# Altium schematic sheet Module_iMX8_plus.SchDoc
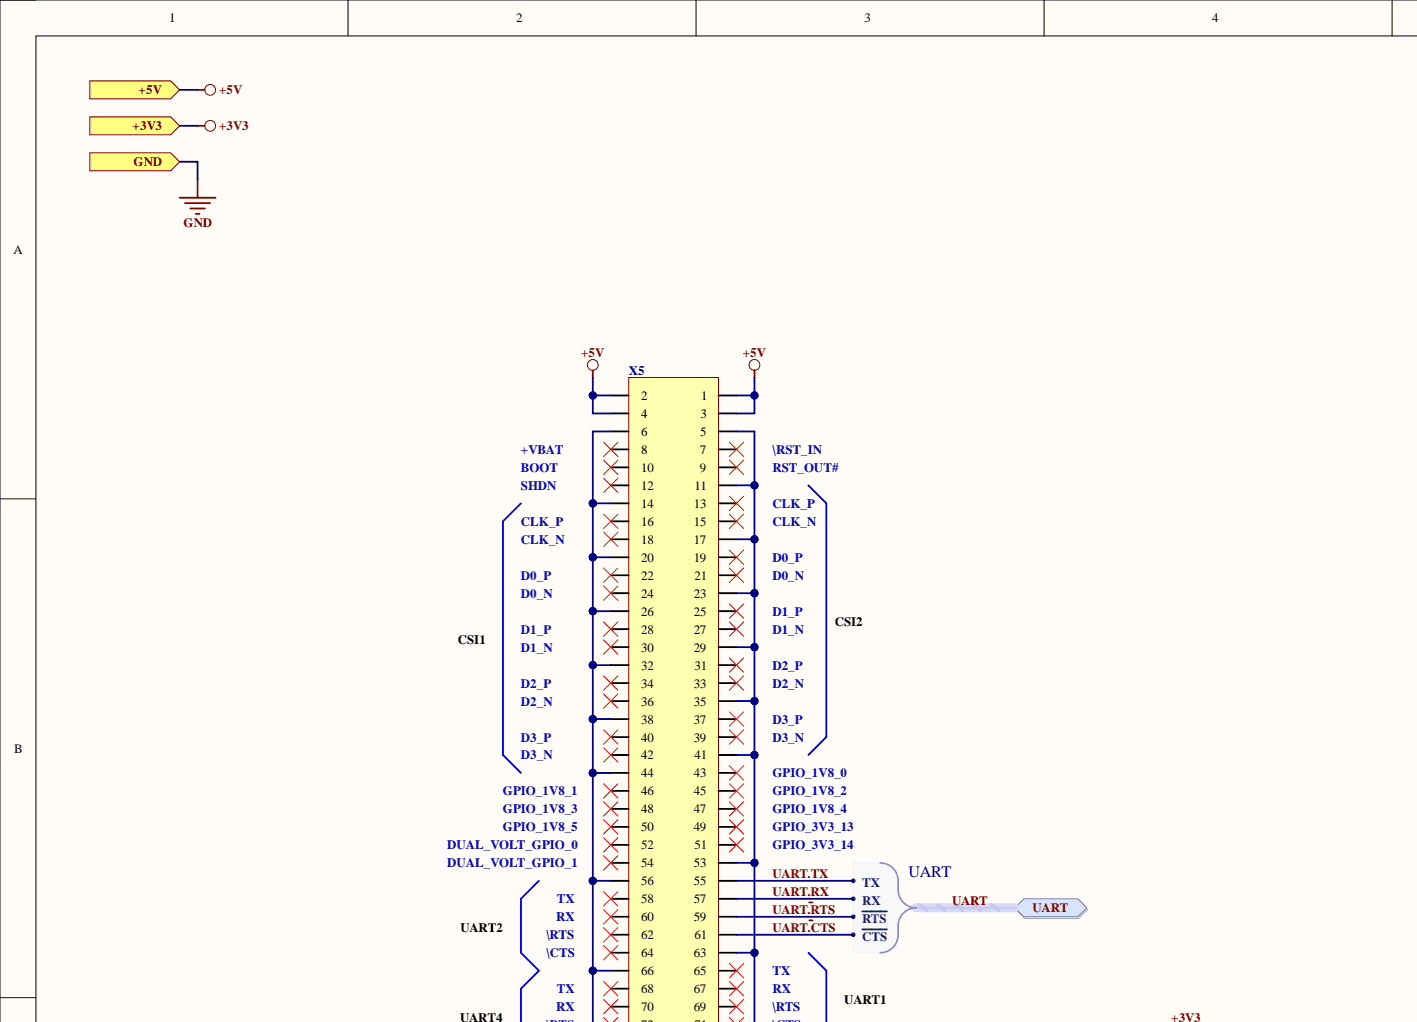
[diagram p0: Module_iMX8_plus.SchDoc, top left]
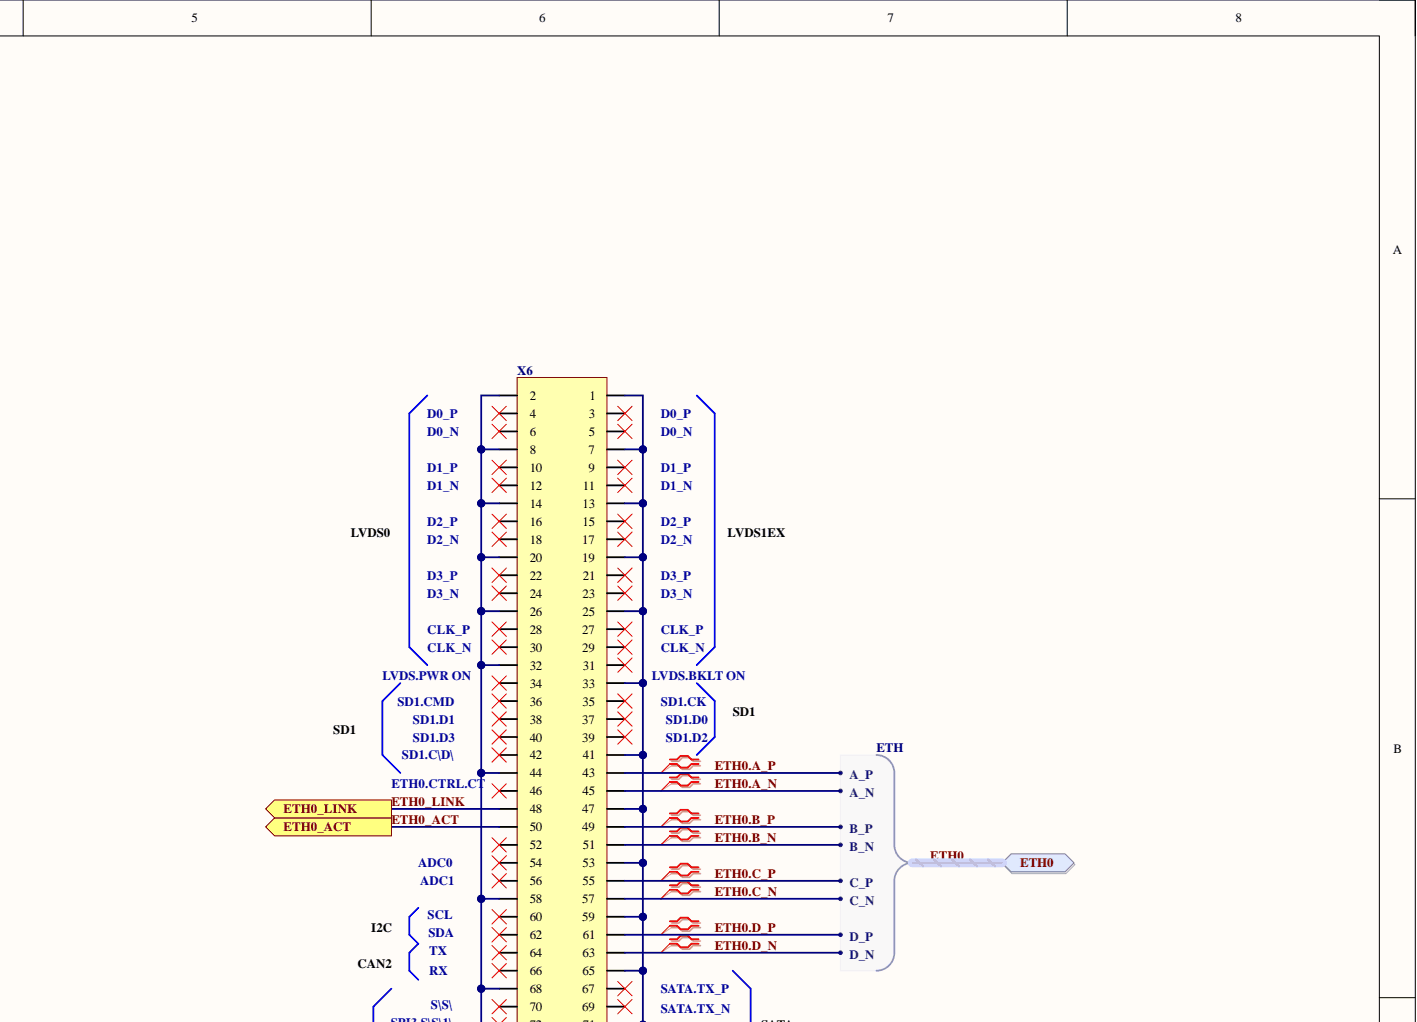
[diagram p1: Module_iMX8_plus.SchDoc, top right]
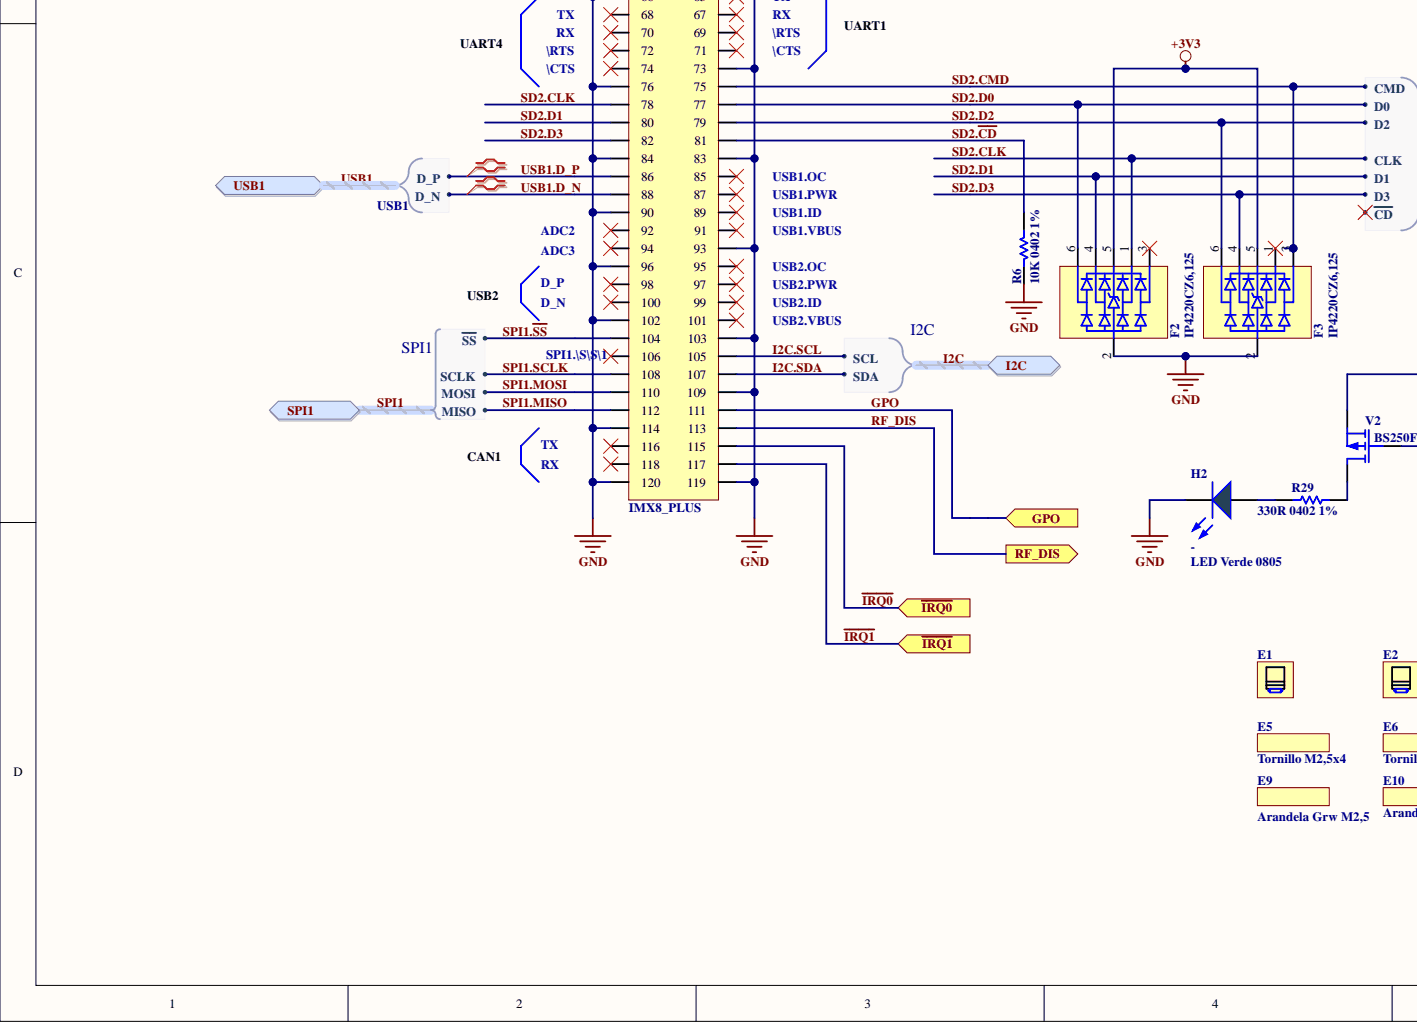
[diagram p2: Module_iMX8_plus.SchDoc, bottom left]
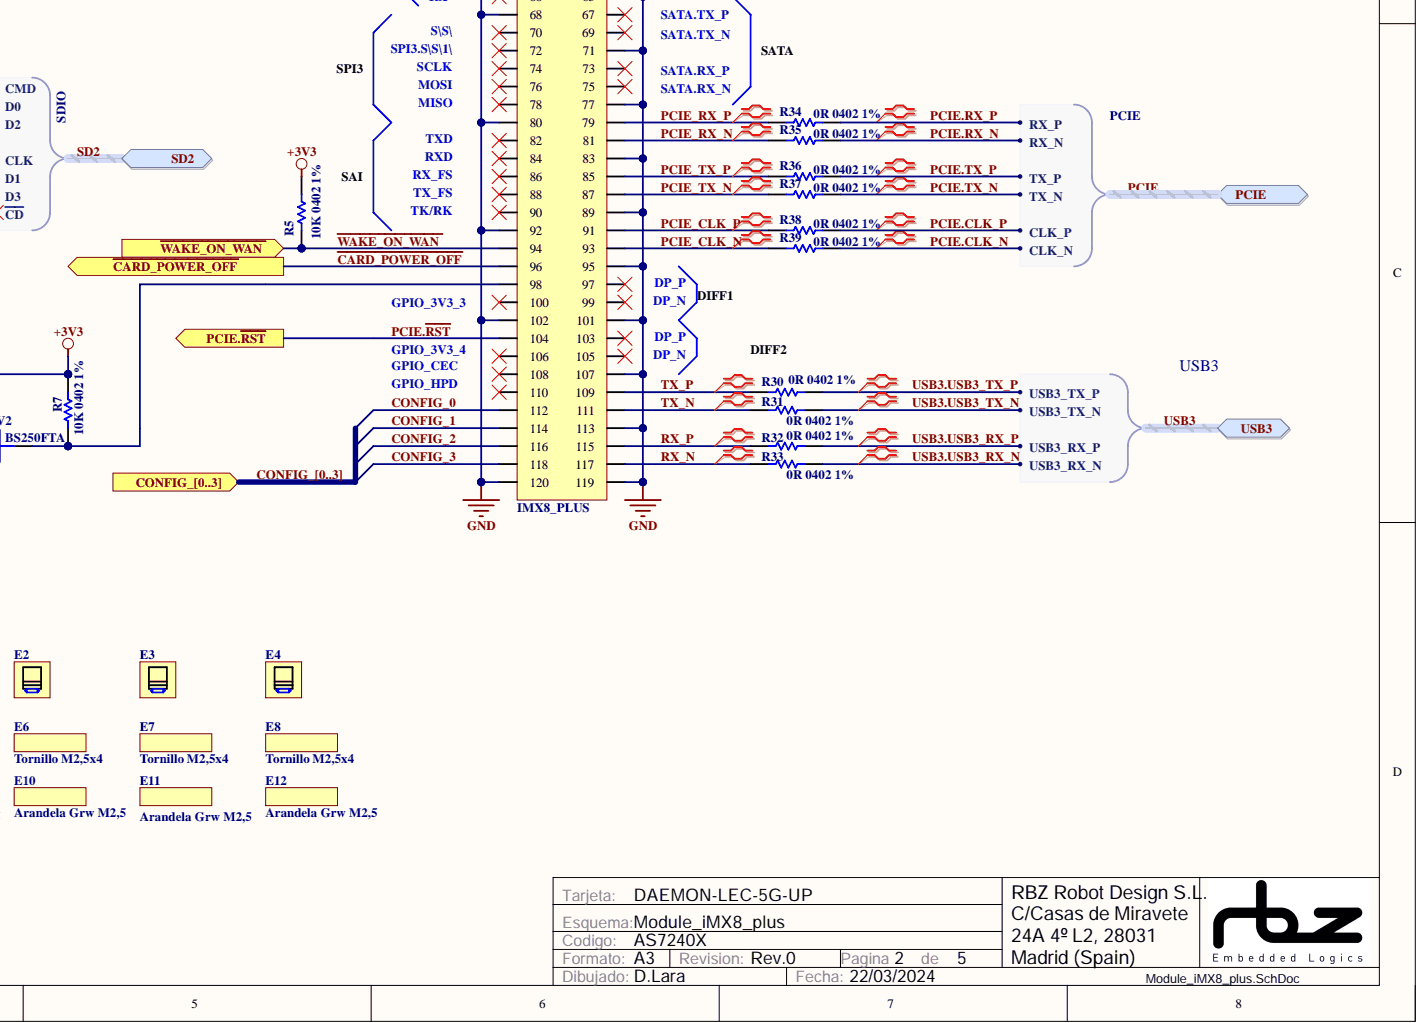
[diagram p3: Module_iMX8_plus.SchDoc, bottom right]

## Parts
E1  ROUND STANDOFF M2.5 STEEL 5MM  [9774050151R]  (value not drawn)
E2  ROUND STANDOFF M2.5 STEEL 5MM  [9774050151R]  (value not drawn)
E3  ROUND STANDOFF M2.5 STEEL 5MM  [9774050151R]  (value not drawn)
E4  ROUND STANDOFF M2.5 STEEL 5MM  [9774050151R]  (value not drawn)
E5  Tornillo M2,5x4  (mechanical)
E6  Tornillo M2,5x4  (mechanical)
E7  Tornillo M2,5x4  (mechanical)
E8  Tornillo M2,5x4  (mechanical)
E9  Arandela Grw M2,5  (mechanical)
E10  Arandela Grw M2,5  (mechanical)
E11  Arandela Grw M2,5  (mechanical)
E12  Arandela Grw M2,5  (mechanical)
F2  IP4220CZ6,125  [SOT26A-6N]
F3  IP4220CZ6,125  [SOT26A-6N]
H2  -  value LED Verde 0805  Type: LED  [D0805(2012)]
R5  10K 0402 1%  [R0402(1005)-HIGH_DENSITY]
R6  10K 0402 1%  [R0402(1005)-HIGH_DENSITY]
R7  10K 0402 1%  [R0402(1005)-HIGH_DENSITY]
R29  330R 0402 1%  [R0402(1005)-HIGH_DENSITY]
R30  0R 0402 1%  [R0402(1005)-HIGH_DENSITY]
R31  0R 0402 1%  [R0402(1005)-HIGH_DENSITY]
R32  0R 0402 1%  [R0402(1005)-HIGH_DENSITY]
R33  0R 0402 1%  [R0402(1005)-HIGH_DENSITY]
R34  0R 0402 1%  [R0402(1005)-HIGH_DENSITY]
R35  0R 0402 1%  [R0402(1005)-HIGH_DENSITY]
R36  0R 0402 1%  [R0402(1005)-HIGH_DENSITY]
R37  0R 0402 1%  [R0402(1005)-HIGH_DENSITY]
R38  0R 0402 1%  [R0402(1005)-HIGH_DENSITY]
R39  0R 0402 1%  [R0402(1005)-HIGH_DENSITY]
V2  BS250FTA  [SOT23]
X5  IMX8_PLUS  [FCI_61083-121402LF]
X6  IMX8_PLUS  [FCI_61083-121402LF]

## Ports
+3V3, +5V, CONFIG_0, CONFIG_1, CONFIG_2, CONFIG_3, CONFIG_[0..3], ETH0, ETH0.A_N, ETH0.A_P, ETH0.B_N, ETH0.B_P, ETH0.C_N, ETH0.C_P, ETH0.D_N, ETH0.D_P, ETH0_ACT, ETH0_LINK, GND, GPO, I2C, I2C.SCL, I2C.SDA, PCIE, PCIE.CLK_N, PCIE.CLK_P, PCIE.RX_N, PCIE.RX_P, PCIE.TX_N, PCIE.TX_P, PCIE.~{RST}, RF_DIS, SD2, SD2.CLK, SD2.CMD, SD2.D0, SD2.D1, SD2.D2, SD2.D3, SD2.~{CD}, SPI1, SPI1.MISO, SPI1.MOSI, SPI1.SCLK, SPI1.~{SS}, UART, UART.RX, UART.TX, UART~{.}CTS, UART~{.}RTS, USB1, USB1.D_N, USB1.D_P, USB3, USB3.USB3_RX_N, USB3.USB3_RX_P, USB3.USB3_TX_N, USB3.USB3_TX_P, ~{CARD_POWER_OFF}, ~{IRQ0}, ~{IRQ1}, ~{WAKE_ON_WAN}

## Nets
+3V3: F2.5 F3.5 R5.1 R7.1 V2.2 ; port +3V3
+5V: X5.1 X5.2 X5.3 X5.4 ; port +5V
~{CARD_POWER_OFF}: X6.96 ; port ~{CARD_POWER_OFF}
~{IRQ0}: X5.115 ; port ~{IRQ0}
~{IRQ1}: X5.117 ; port ~{IRQ1}
~{WAKE_ON_WAN}: R5.2 X6.94 ; port ~{WAKE_ON_WAN}
CONFIG_0: X6.112 ; port CONFIG_[0..3]  [also labelled CONFIG_]
CONFIG_1: X6.114 ; port CONFIG_[0..3]  [also labelled CONFIG_]
CONFIG_2: X6.116 ; port CONFIG_[0..3]  [also labelled CONFIG_]
CONFIG_3: X6.118 ; port CONFIG_[0..3]  [also labelled CONFIG_]
ETH0.A_N: X6.45 X6.48 X6.50 ; port ETH0, port ETH0_ACT, port ETH0_LINK  [also labelled ETH0_ACT, ETH0_LINK]
ETH0.A_P: X6.43 ; port ETH0
ETH0.B_N: X6.51 ; port ETH0
ETH0.B_P: X6.49 ; port ETH0
ETH0.C_N: X6.57 ; port ETH0
ETH0.C_P: X6.55 ; port ETH0
ETH0.D_N: X6.63 ; port ETH0
ETH0.D_P: X6.61 ; port ETH0
GND: F2.2 F3.2 H2.2 R6.1 X5.5 X5.6 X5.11 X5.14 X5.17 X5.20 X5.23 X5.26 X5.29 X5.32 X5.35 X5.38 X5.41 X5.44 X5.53 X5.56 X5.63 X5.66 X5.73 X5.76 X5.83 X5.84 X5.90 X5.93 X5.96 X5.102 X5.103 X5.109 X5.114 X5.119 X5.120 X6.1 X6.2 X6.7 X6.8 X6.13 X6.14 X6.19 X6.20 X6.25 X6.26 X6.32 X6.33 X6.41 X6.44 X6.47 X6.53 X6.58 X6.59 X6.65 X6.68 X6.71 X6.77 X6.80 X6.83 X6.89 X6.92 X6.95 X6.101 X6.102 X6.107 X6.113 X6.119 X6.120 ; port GND
GPO: X5.111 ; port GPO
I2C.SCL: X5.105 ; port I2C
I2C.SDA: X5.107 ; port I2C
PCIE.CLK_N: R39.2 ; port PCIE
PCIE.CLK_P: R38.2 ; port PCIE
PCIE.~{RST}: X6.104 ; port PCIE.~{RST}
PCIE.RX_N: R35.2 ; port PCIE
PCIE.RX_P: R34.2 ; port PCIE
PCIE.TX_N: R37.2 ; port PCIE
PCIE.TX_P: R36.2 ; port PCIE
PCIE_CLK_N: R39.1 X6.93
PCIE_CLK_P: R38.1 X6.91
PCIE_RX_N: R35.1 X6.81
PCIE_RX_P: R34.1 X6.79
PCIE_TX_N: R37.1 X6.87
PCIE_TX_P: R36.1 X6.85
RF_DIS: X5.113 ; port RF_DIS
RX_N: R33.1 X6.117
RX_P: R32.1 X6.115
SD2.~{CD}: R6.2 X5.81 ; port SD2
SD2.CLK: F2.1 X5.78 ; port SD2
SD2.CMD: F3.3 X5.75 ; port SD2
SD2.D0: F2.6 X5.77 ; port SD2
SD2.D1: F2.4 X5.80 ; port SD2
SD2.D2: F3.6 X5.79 ; port SD2
SD2.D3: F3.4 X5.82 ; port SD2
SPI1.MISO: X5.112 ; port SPI1
SPI1.MOSI: X5.110 ; port SPI1
SPI1.~{SS}: X5.104 ; port SPI1
SPI1.SCLK: X5.108 ; port SPI1
TX_N: R31.1 X6.111
TX_P: R30.1 X6.109
UART~{.}CTS: X5.61 ; port UART
UART~{.}RTS: X5.59 ; port UART
UART.RX: X5.57 ; port UART
UART.TX: X5.55 ; port UART
USB1.D_N: X5.88 ; port USB1
USB1.D_P: X5.86 ; port USB1
USB3.USB3_RX_N: R33.2 ; port USB3
USB3.USB3_RX_P: R32.2 ; port USB3
USB3.USB3_TX_N: R31.2 ; port USB3
USB3.USB3_TX_P: R30.2 ; port USB3
NetF2_3: F2.3
NetF3_1: F3.1
NetH2_1: H2.1 R29.1
NetR7_2: R7.2 V2.1 X6.98
NetR29_2: R29.2 V2.3
NetX5_7: X5.7
NetX5_8: X5.8
NetX5_9: X5.9
NetX5_10: X5.10
NetX5_12: X5.12
NetX5_13: X5.13
NetX5_15: X5.15
NetX5_16: X5.16
NetX5_18: X5.18
NetX5_19: X5.19
NetX5_21: X5.21
NetX5_22: X5.22
NetX5_24: X5.24
NetX5_25: X5.25
NetX5_27: X5.27
NetX5_28: X5.28
NetX5_30: X5.30
NetX5_31: X5.31
NetX5_33: X5.33
NetX5_34: X5.34
NetX5_36: X5.36
NetX5_37: X5.37
NetX5_39: X5.39
NetX5_40: X5.40
NetX5_42: X5.42
NetX5_43: X5.43
NetX5_45: X5.45
NetX5_46: X5.46
NetX5_47: X5.47
NetX5_48: X5.48
NetX5_49: X5.49
NetX5_50: X5.50
NetX5_51: X5.51
NetX5_52: X5.52
NetX5_54: X5.54
NetX5_58: X5.58
NetX5_60: X5.60
NetX5_62: X5.62
NetX5_64: X5.64
NetX5_65: X5.65
NetX5_67: X5.67
NetX5_68: X5.68
NetX5_69: X5.69
NetX5_70: X5.70
NetX5_71: X5.71
NetX5_72: X5.72
NetX5_74: X5.74
NetX5_85: X5.85
NetX5_87: X5.87
NetX5_89: X5.89
NetX5_91: X5.91
NetX5_92: X5.92
NetX5_94: X5.94
NetX5_95: X5.95
NetX5_97: X5.97
NetX5_98: X5.98
NetX5_99: X5.99
NetX5_100: X5.100
NetX5_101: X5.101
NetX5_106: X5.106
NetX5_116: X5.116
NetX5_118: X5.118
NetX6_3: X6.3
NetX6_4: X6.4
NetX6_5: X6.5
NetX6_6: X6.6
NetX6_9: X6.9
NetX6_10: X6.10
NetX6_11: X6.11
NetX6_12: X6.12
NetX6_15: X6.15
NetX6_16: X6.16
NetX6_17: X6.17
NetX6_18: X6.18
NetX6_21: X6.21
NetX6_22: X6.22
NetX6_23: X6.23
NetX6_24: X6.24
NetX6_27: X6.27
NetX6_28: X6.28
NetX6_29: X6.29
NetX6_30: X6.30
NetX6_31: X6.31
NetX6_34: X6.34
NetX6_35: X6.35
NetX6_36: X6.36
NetX6_37: X6.37
NetX6_38: X6.38
NetX6_39: X6.39
NetX6_40: X6.40
NetX6_42: X6.42
NetX6_46: X6.46
NetX6_52: X6.52
NetX6_54: X6.54
NetX6_56: X6.56
NetX6_60: X6.60
NetX6_62: X6.62
NetX6_64: X6.64
NetX6_66: X6.66
NetX6_67: X6.67
NetX6_69: X6.69
NetX6_70: X6.70
NetX6_72: X6.72
NetX6_73: X6.73
NetX6_74: X6.74
NetX6_75: X6.75
NetX6_76: X6.76
NetX6_78: X6.78
NetX6_82: X6.82
NetX6_84: X6.84
NetX6_86: X6.86
NetX6_88: X6.88
NetX6_90: X6.90
NetX6_97: X6.97
NetX6_99: X6.99
NetX6_100: X6.100
NetX6_103: X6.103
NetX6_105: X6.105
NetX6_106: X6.106
NetX6_108: X6.108
NetX6_110: X6.110

## Library data of the parts
E1-E4 = 9774050151R: Fabricante: Wurth; Precio: 1.09€; Ref. Catalogo: 0.83€ @100; Component Kind: Standard; Description: ROUND STANDOFF M2.5 STEEL 5MM; Distribuidor: -; Montaje: -; Referencia: 9774050151R; Serigrafia: -; Value: ROUND STANDOFF M2.5 STEEL 5MM; HelpURL: S:\Mechanical_Parts\Datasheets\Wurth_9774050151R.pdf; Library Name: Spacers.SchLib
E5-E8 = Tornillo M2,5x4  DIN 7985: Fabricante: Kraftberg; Precio: 0,009€ @100; Precio Prod.: 0,0045€ @5000; Ref. Catalogo: (TME) M2.5X4/D7985B; Referencia: M2.5X4/D7985B; Description: Tornillo M2,5x4  DIN 7985; Distribuidor: T.M.E.; Montaje: -; Value: Tornillo M2,5x4; Library Name: Tornillos.SchLib
E9-E12 = Arandela Grower M2,5 DIN67975: Fabricante: Duratool; Precio: 0,0199€; Precio Prod.: 0,0187€ @2500; Ref. Catalogo: (F) 1624046; Referencia: D01152; Description: Arandela Grower M2,5 DIN67975; Distribuidor: -; Montaje: -; Value: Arandela Grw M2,5; HelpURL: S:\Mechanical_Parts\datasheets\Duratool_301949.pdf; Library Name: Arandelas.SchLib
F2 F3 = IP4220CZ6,125: USB DUAL ESD PROTECT 6TSOP; Fabricante: NXP; Precio: 0,44€; Precio Prod.: 0,12€ @1000; Ref. Catalogo: (D) 568-3529-1-ND; Component Kind: Standard; Distribuidor: -; Montaje: -; Referencia: IP4220CZ6,125; Value: USB DUAL ESD PROTECT 6TSOP; HelpURL: S:\Protecciones\datasheets\NXP IP4220CZ6_4.pdf; Library Name: Protecciones ESD.SchLib
H2 = APT2012SGC: LED Green SMD 0805 70mcd @ 5mA; Codigo RBZ: KIN_APT2012SGC; Fabricante: Kingbright; Inspected: False; Precio: 0,1€; Precio Prod.: 0,03€ @2000; Ref. Catalogo: (D) 754-1131-1-ND; Component Kind: Standard; Distribuidor: -; Halogen Free: -; Montaje: -; REACH: -; Referencia: APT2012SGC; RoHS: True; Serigrafia: -; Simulation: LED0; HelpURL: S:\LEDs\datasheets\Kingbright_APT2012SGC.pdf; Library Name: Color Emitting Diodes.SchLib
R5-R7 = R0402F010K: Resistencia genérica 0402 1% 10K; Fabricante: Vishay; Inspected: True; Precio: 0,08€; Precio Prod.: 0,0041€ @1000; Ref. Catalogo: (D) 541-3959-1-ND; Referencia: CRCW040210K0FKEDC; Codigo RBZ: R0402F010K; Component Kind: Standard; Distribuidor: -; Halogen Free: -; Library Name: Resistor_Generic.SchLib; Montaje: -; REACH: -; RoHS: True; Type: Resistor; Value: 10K 0402 1%; HelpURL: S:\Resistencias\Datasheets\Vishay_crcwce3.pdf
R29 = R0402F330R: Resistencia genérica 0402 1% 330R; Fabricante: Panasonic; Inspected: False; Precio: 0,08€; Precio Prod.: 0,0047€ @5000; Ref. Catalogo: (D) P330LCT-ND; Referencia: ERJ-2RKF3300X; Codigo RBZ: R0402F330R; Component Kind: Standard; Distribuidor: -; Halogen Free: -; Library Name: Resistor_Generic.SchLib; Montaje: -; REACH: -; RoHS: True; Type: Resistor; Value: 330R 0402 1%; HelpURL: S:\Resistencias\Datasheets\AOA0000C304.pdf
R30-R39 = R0402F000R: Resistencia genérica 0402 1% 0R; Fabricante: Panasonic; Inspected: False; Precio: 0,08€; Precio Prod.: 0,0047€ @5000; Ref. Catalogo: (D) P0.0JCT-ND; Referencia: ERJ-2GE0R00X; Codigo RBZ: R0402F000R; Component Kind: Standard; Distribuidor: -; Halogen Free: -; Library Name: Resistor_Generic.SchLib; Montaje: -; REACH: -; RoHS: True; Type: Resistor; Value: 0R 0402 1%; HelpURL: S:\Resistencias\Datasheets\AOA0000C304.pdf
V2 = BS250FTA: MOSFET P-CH, 90mA, 20V; Fabricante: Zetex; Inspected: False; Precio: 0.84€; Ref. Catalogo: (D) BS250FCT-ND; Codigo RBZ: -; Component Kind: Standard; Distribuidor: -; Halogen Free: -; Library Name: Transistores MOSFET.SchLib; Montaje: -; Precio Prod.: -; REACH: -; Referencia: BS250FTA; RoHS: -; Type: Transistor_MOSFET; Value: -; HelpURL: s:\transistores\datasheets\Zetex_BS250F.pdf
X5 X6 = 61083-121402LF: Bergstak 0.8mm Mezzanine Con. Plug 120p 4.7mm Height SMT; Fabricante: FCI; Precio: 7.6€; Precio Prod.: 5.41€ @250; Ref. Catalogo: (D) 609-1699-1-ND; Component Kind: Standard; ComponentLink1Description: Mechanical Drawings; Distribuidor: RC-Micro; Library Name: FCI.SchLib; Montaje: -; Referencia: 61083-121402LF; Serigrafia: IMX8_PLUS; Value: Bergstak 0.8mm Mezzanine Con. Plug 120p 4.7mm Height SMT; ComponentLink1URL: S:\Conectores\datasheets\FCI_61083.pdf; HelpURL: S:\Conectores\datasheets\FCI_950547-001 bergstak_b.pdf
